# Altium schematic sheet S7CAS-PLEIADES-CARTE-MERE_P01_ETHERNET.SchDoc
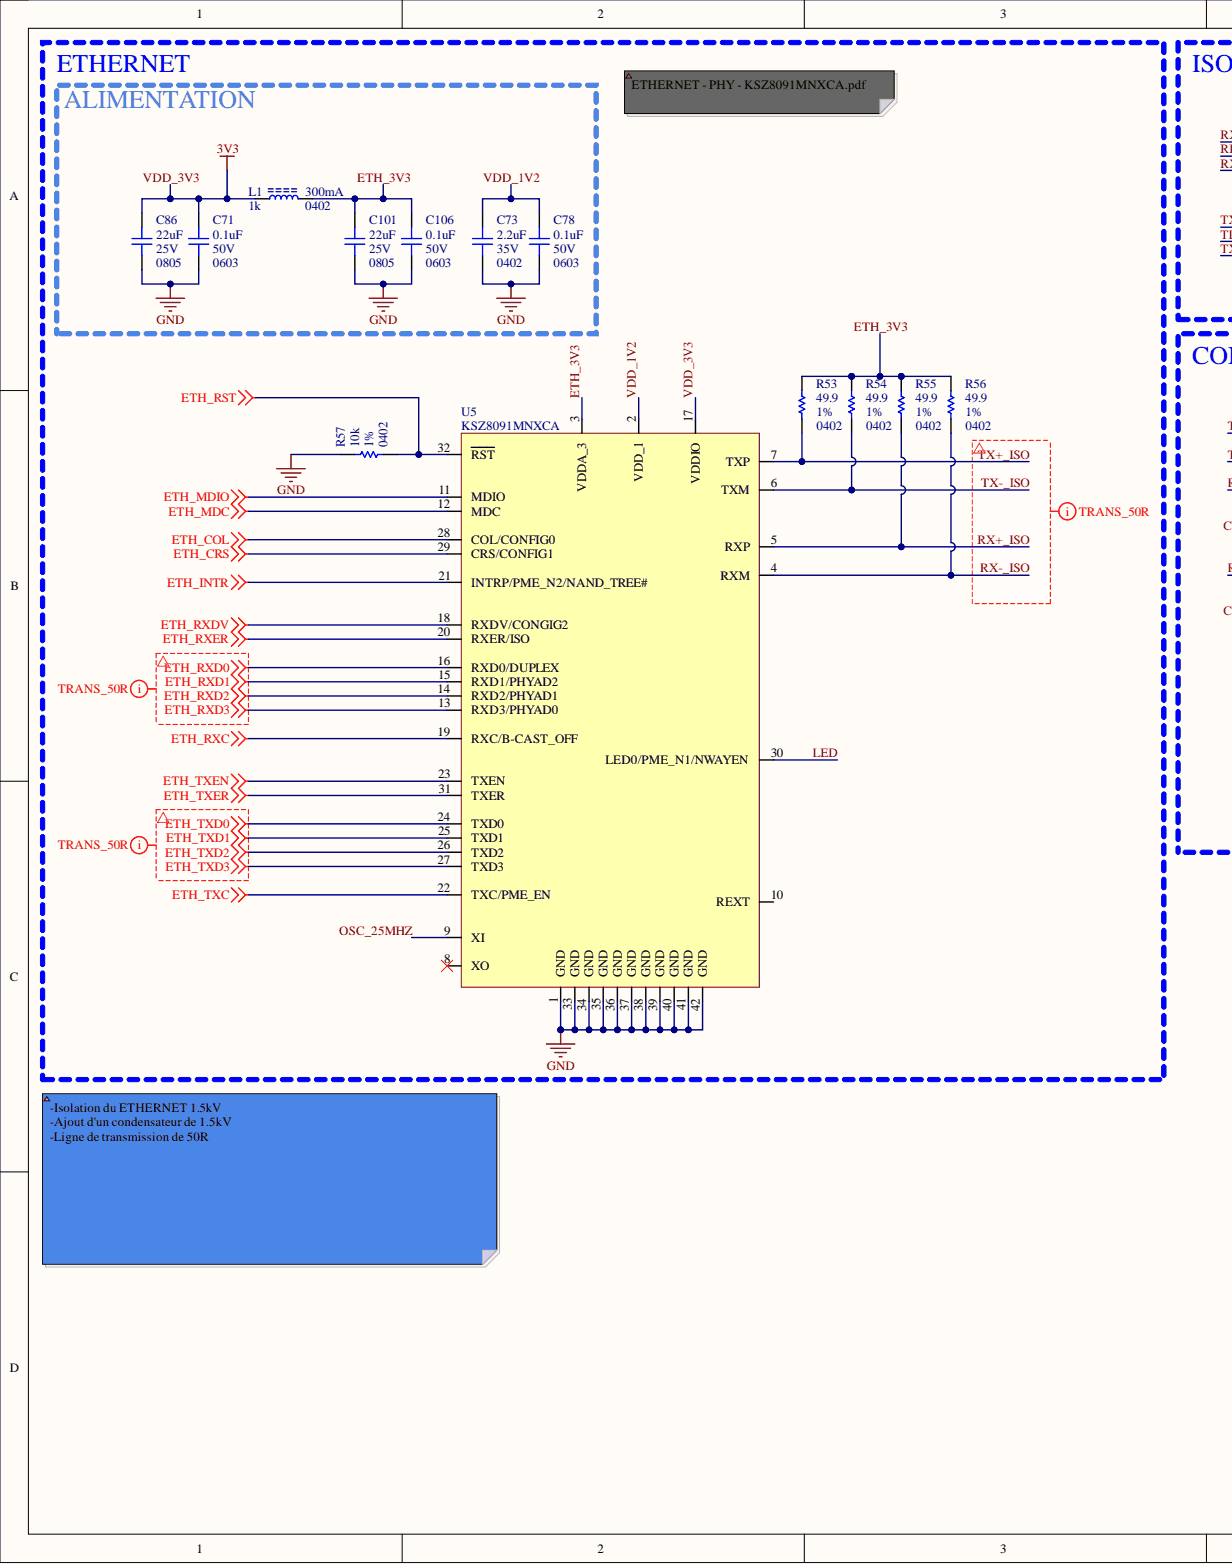
[diagram p0: S7CAS-PLEIADES-CARTE-MERE_P01_ETHERNET.SchDoc, left]
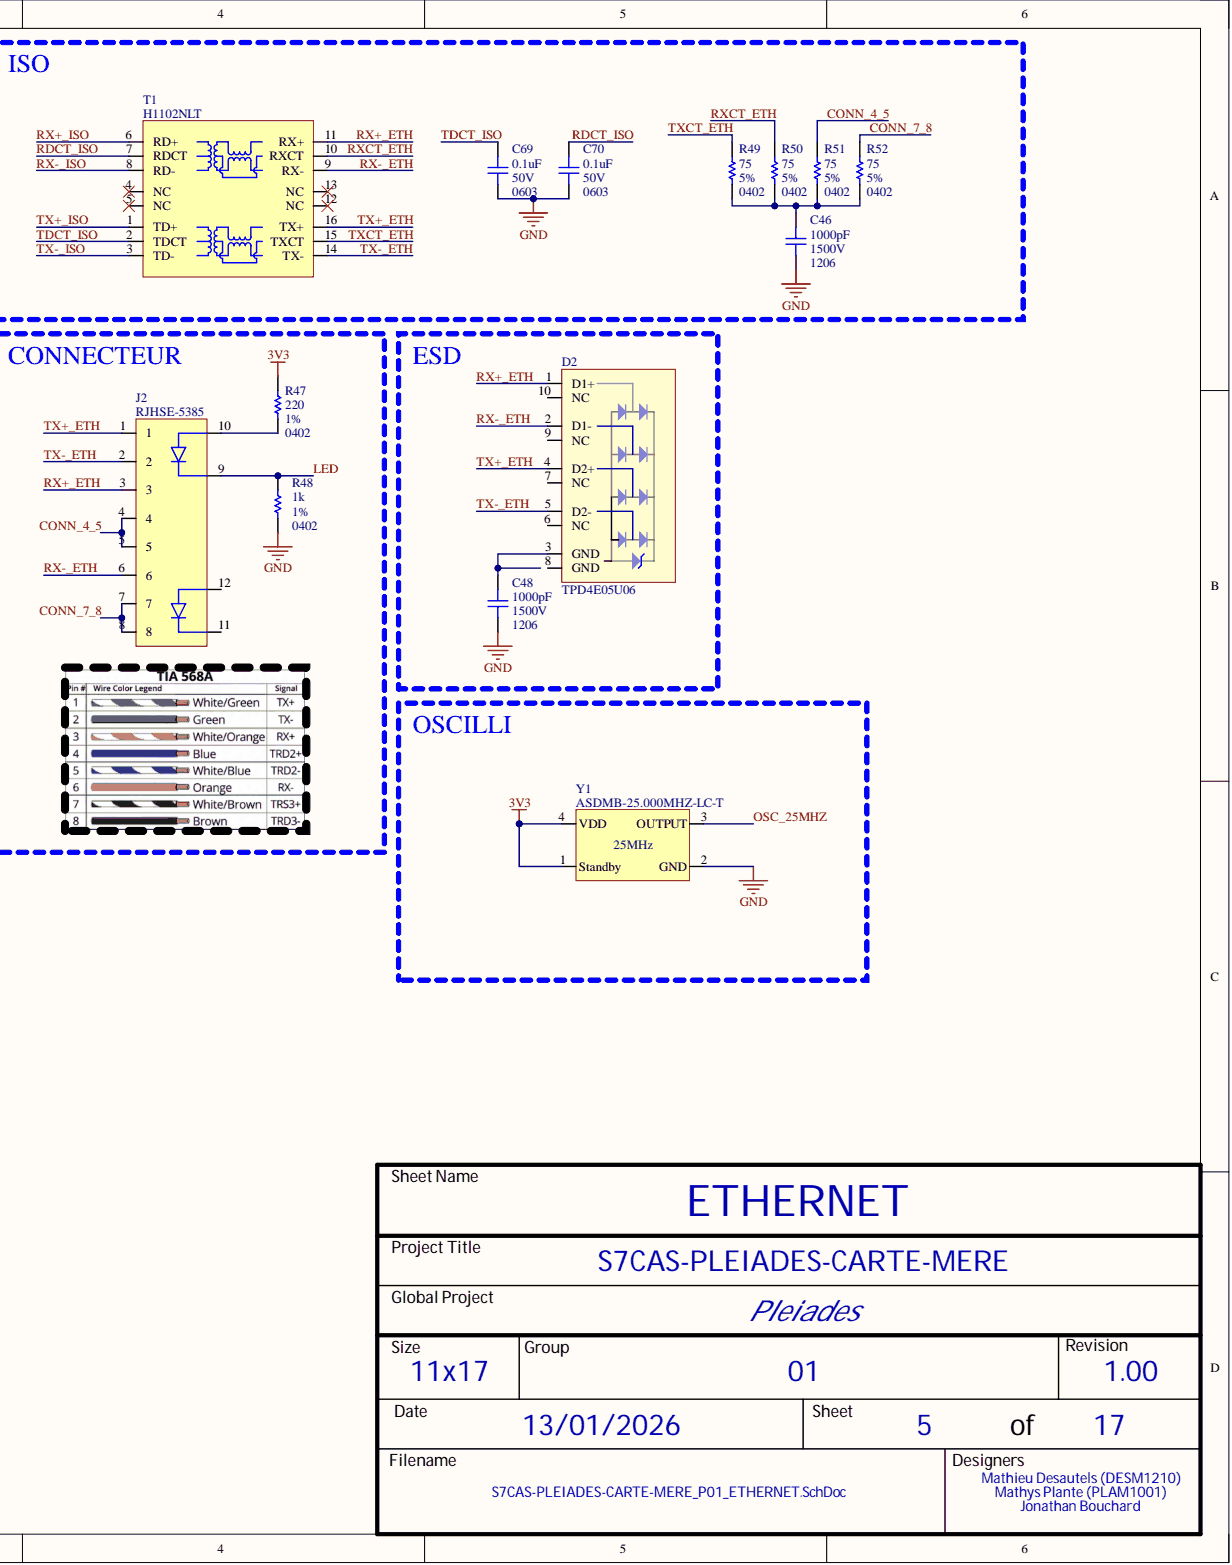
[diagram p1: S7CAS-PLEIADES-CARTE-MERE_P01_ETHERNET.SchDoc, right]

## Parts
C46  value 1000pF  Package: 1206  Voltage: 1500V  [CAP_1206]  (hidden comment: CAP - 1206)
C48  value 1000pF  Package: 1206  Voltage: 1500V  [CAP_1206]  (hidden comment: CAP - 1206)
C69  value 0.1uF  Package: 0603  Voltage: 50V  [CAP_0603]  (hidden comment: CAP - 0603)
C70  value 0.1uF  Package: 0603  Voltage: 50V  [CAP_0603]  (hidden comment: CAP - 0603)
C71  value 0.1uF  Package: 0603  Voltage: 50V  [CAP_0603]  (hidden comment: CAP - 0603)
C73  value 2.2uF  Package: 0402  Voltage: 35V  [CAP_0402]  (hidden comment: CAP - 0402)
C78  value 0.1uF  Package: 0603  Voltage: 50V  [CAP_0603]  (hidden comment: CAP - 0603)
C86  value 22uF  Package: 0805  Voltage: 25V  [CAP_0805]  (hidden comment: CAP - 0805)
C101  value 22uF  Package: 0805  Voltage: 25V  [CAP_0805]  (hidden comment: CAP - 0805)
C106  value 0.1uF  Package: 0603  Voltage: 50V  [CAP_0603]  (hidden comment: CAP - 0603)
D2  TPD4E05U06  [TVS_DATA_TPD4E05U06]
J2  RJHSE-5385  [CONN_ETHERNET_RJHSE-5385]
L1  value 1k  Current: 300mA  Package: 0402  [FER_0402]  (hidden comment: FER - 0402)
R47  value 220  Package: 0402  Precision: 1%  [RES_0402]  (hidden comment: RES - 0402)
R48  value 1k  Package: 0402  Precision: 1%  [RES_0402]  (hidden comment: RES - 0402)
R49  value 75  Package: 0402  Precision: 5%  [RES_0402]  (hidden comment: RES - 0402)
R50  value 75  Package: 0402  Precision: 5%  [RES_0402]  (hidden comment: RES - 0402)
R51  value 75  Package: 0402  Precision: 5%  [RES_0402]  (hidden comment: RES - 0402)
R52  value 75  Package: 0402  Precision: 5%  [RES_0402]  (hidden comment: RES - 0402)
R53  value 49.9  Precision: 1%  [RES_0402]  (hidden comment: RES - 0402)
R54  value 49.9  Package: 0402  Precision: 1%  [RES_0402]  (hidden comment: RES - 0402)
R55  value 49.9  Package: 0402  Precision: 1%  [RES_0402]  (hidden comment: RES - 0402)
R56  value 49.9  Package: 0402  Precision: 1%  [RES_0402]  (hidden comment: RES - 0402)
R57  value 10k  Package: 0402  Precision: 1%  [RES_0402]  (hidden comment: RES - 0402)
T1  H1102NLT  [TRANSFO_H1102NLT]
U5  KSZ8091MNXCA  [COM_ETH_PHY_KSZ8091MNXCA]
Y1  ASDMB-25.000MHZ-LC-T  value 25MHz  [OSC_ASDMB-25.000MHZ-LC-T]

## Nets
ETH_COL: U5.28(COL/CONFIG0)
ETH_CRS: U5.29(CRS/CONFIG1)
ETH_INTR: U5.21(INTRP/PME_N2/NAND_TREE#)
ETH_MDC: U5.12(MDC)
ETH_MDIO: U5.11(MDIO)
ETH_RST: R57.2 U5.32(RST)
ETH_RXC: U5.19(RXC/B-CAST_OFF)
ETH_RXD0: U5.16(RXD0/DUPLEX)
ETH_RXD1: U5.15(RXD1/PHYAD2)
ETH_RXD2: U5.14(RXD2/PHYAD1)
ETH_RXD3: U5.13(RXD3/PHYAD0)
ETH_RXDV: U5.18(RXDV/CONGIG2)
ETH_RXER: U5.20(RXER/ISO)
ETH_TXC: U5.22(TXC/PME_EN)
ETH_TXD0: U5.24(TXD0)
ETH_TXD1: U5.25(TXD1)
ETH_TXD2: U5.26(TXD2)
ETH_TXD3: U5.27(TXD3)
ETH_TXEN: U5.23(TXEN)
ETH_TXER: U5.31(TXER)
GND: C46.1 C48.1 C69.1 C70.1 C71.1 C73.1 C78.1 C86.1 C101.1 C106.1 R48.1 R57.1 U5.1(GND) U5.33(GND) U5.34(GND) U5.35(GND) U5.36(GND) U5.37(GND) U5.38(GND) U5.39(GND) U5.40(GND) U5.41(GND) U5.42(GND) Y1.2(GND)
CONN_4_5: J2.4 J2.5 R51.2
CONN_7_8: J2.7 J2.8 R52.2
ETH_3V3: C101.2 C106.2 L1.1 R53.2 R54.2 R55.2 R56.2 U5.3(VDDA_3)
LED: J2.9 R48.2 U5.30(LED0/PME_N1/NWAYEN)
OSC_25MHZ: U5.9(XI) Y1.3(OUTPUT)
RDCT_ISO: C70.2 T1.7(RDCT)
RX+_ETH: D2.1(D1+) J2.3 T1.11(RX+)
RX+_ISO: R55.1 T1.6(RD+) U5.5(RXP)
RX-_ETH: D2.2(D1-) J2.6 T1.9(RX-)
RX-_ISO: R56.1 T1.8(RD-) U5.4(RXM)
RXCT_ETH: R50.2 T1.10(RXCT)
TDCT_ISO: C69.2 T1.2(TDCT)
TX+_ETH: D2.4(D2+) J2.1 T1.16(TX+)
TX+_ISO: R53.1 T1.1(TD+) U5.7(TXP)
TX-_ETH: D2.5(D2-) J2.2 T1.14(TX-)
TX-_ISO: R54.1 T1.3(TD-) U5.6(TXM)
TXCT_ETH: R49.2 T1.15(TXCT)
VDD_1V2: C73.2 C78.2 U5.2(VDD_1)
VDD_3V3: C71.2 C86.2 L1.2 R47.2 U5.17(VDDIO) Y1.1(Standby) Y1.4(VDD)
NetC46_2: C46.2 R49.1 R50.1 R51.1 R52.1
NetC48_2: C48.2 D2.3(GND)
NetJ2_10: J2.10 R47.1

Not connected: D2 6(NC) 7(NC) 8(GND) 9(NC) 10(NC); J2 11 12; T1 4(NC) 5(NC) 12(NC) 13(NC); U5 8(XO) 10(REXT)

## Library data of the parts
C46 C48 = CAP - 1206: 1000 pF ±5% 1500V (1.5kV) Ceramic Capacitor C0G, NP0 1206 (3216 Metric); Mfg Part Number: VJ1206A102JXRAT5Z; Mfg: Vishay Vitramon; Component Kind: Standard; Library Name: s7cas_lib2023.intlib; Part Number #1: VJ1206A102JXRAT5Z; Precision: 5%; CreatedBy: Jonathan Bouchard
C69-C71 C78 C106 = CAP - 0603: 0.1 µF ±10% 50V Ceramic Capacitor X7R 0603 (1608 Metric); Mfg Part Number: C0603C104K5RACTU; Mfg: KEMET; Component Kind: Standard; Library Name: s7cas_lib2023.intlib; Precision: 10%; CreatedBy: Jonathan Bouchard
C73 = CAP - 0402: 2.2 µF ±10% 35V Ceramic Capacitor X5R 0402 (1005 Metric); Mfg Part Number: GRM155R6YA225KE11D; Part Number #1: CL05A225KP5NSNC; Mfg: Murata Electronics; Component Kind: Standard; Library Name: s7cas_lib2023.intlib; Precision: 10%; CreatedBy: Jonathan Bouchard
C86 C101 = CAP - 0805: 22 µF ±20% 25V Ceramic Capacitor X5R 0805 (2012 Metric); Mfg Part Number: CL21A226MAYNNNE; Mfg: Samsung Electro-Mechanics; Component Kind: Standard; Library Name: s7cas_lib2023.intlib; Precision: 20%; CreatedBy: Jonathan Bouchard
D2 = TVS_DATA_TPD4E05U06: TVS - TVS DIODE 5.5VWM 14VC - 10SON; Manufacturer #1: Texas Instruments; Mfg Part Number: TPD4E05U06DQAR; Cryo: UNKNOWN; Current Rating: 2.5A (8/20µs); GRAMS Lib Spec: 2.8; Polarized: YES; Power Max: 40 W (peak); Value: 4 unidirectionnal channels; Voltage rating: 6V (Breakdown); Component Kind: Standard; HelpURL: http://www.ti.com/lit/ds/symlink/tpd4e05u06.pdf; Library Name: s7cas_lib2023.intlib; Magnetic Material: UNKNOWN; Package: 10-USON; Part Number #1: TPD4E05U06DQAR; Supplier 1: Digi-Key; Supplier Part Number 1: 296-35765-1-ND; Temperature: -40°C ~ 125°C; CreatedBy/Date: Olivier Bernard / 12/3/2018; VerifiedBy: Caroline Paulin / 4 juillet 2018
J2 = CONN_ETHERNET_RJHSE-5385: CONN - MOD JACK RJ45 R/A ETHERNET - TH; Mfg: Amphenol; Component Kind: Standard; HelpURL: https://www.amphenolcanada.com/ProductSearch/drawings/AC/RJHSE538X.pdf; Library Name: s7cas_lib2023.intlib; Mfg Part Number: RJHSE-5385; Package: TH-12; Part Number #1: RJHSE-5385; Supplier 1: Digikey; Supplier Part Number 1: RJHSE-5385-ND; Temperature: -55°C ~ 85°C; CreatedBy: Jonathan Bouchard
L1 = FER - 0402: 1 kOhms @ 100 MHz 1 Ferrite Bead 0402 (1005 Metric) 300mA 650mOhm; Mfg Part Number: BLM15AG102SN1D; Mfg: Murata Electronics; Component Kind: Standard; Library Name: s7cas_lib2023.intlib; Part Number #1: BLM15AG102SN1D; CreatedBy: Jonathan Bouchard
R47 = RES - 0402: 220 Ohms ±1% 0.1W, 1/10W Chip Resistor 0402 (1005 Metric) Automotive AEC-Q200 Thick Film220; Mfg Part Number: ERJ-2RKF2200X; Mfg: Panasonic Electronic Components; Voltage: 50V; Component Kind: Standard; Library Name: s7cas_lib2023.intlib; Part Number #1: ERJ-2RKF2200X; Power: 1/10W; CreatedBy: Jonathan Bouchard
R48 = RES - 0402: 1 kOhms ±1% 0.1W, 1/10W Chip Resistor 0402 (1005 Metric) Automotive AEC-Q200 Thick Film; Mfg Part Number: ERJ-2RKF1001X; Mfg: Panasonic Electronic Components; Voltage: 50V; Component Kind: Standard; Library Name: s7cas_lib2023.intlib; Part Number #1: ERJ-2RKF1001X; Power: 1/10W; CreatedBy: Jonathan Bouchard
R49-R52 = RES - 0402: 75 Ohms ±5% 0.063W, 1/16W Chip Resistor 0402 (1005 Metric) Moisture Resistant Thick Film; Mfg Part Number: RC0402JR-0775RL; Mfg: YAGEO; Voltage: 50V; Component Kind: Standard; Library Name: s7cas_lib2023.intlib; Part Number #1: RC0402JR-0775RL; Power: 1/16W; CreatedBy: Jonathan Bouchard
R53-R56 = RES - 0402: 49.9 Ohms ±1% 0.1W, 1/10W Chip Resistor 0402 (1005 Metric) Automotive AEC-Q200 Thick Film; Mfg Part Number: CRCW040249R9FKED; Mfg: Vishay Dale; Voltage: 75V; Component Kind: Standard; Library Name: s7cas_lib2023.intlib; Part Number #1: CRCW040249R9FKED; Power: 1/10W; CreatedBy: Jonathan Bouchard
  R53: Package: 0402
R57 = RES - 0402: 10 kOhms ±1% 0.063W, 1/16W Chip Resistor 0402 (1005 Metric) Automotive AEC-Q200 Thick Film; Mfg Part Number: RMCF0402FT10K0; Voltage: 75V; Component Kind: Standard; Library Name: s7cas_lib2023.intlib; Part Number #1: RMCF0402FT10K0; Power: 1/16W; CreatedBy: Jonathan Bouchard
T1 = TRANSFO_H1102NLT: TRANSFO - ETHERNET 10/100B-TX - SMD-16; Mfg: Pulse Eng.; Value: 1:1 (350uH); Component Kind: Standard; HelpURL: http://media.digikey.com/pdf/Data%20Sheets/Pulse%20PDFs/10_100BASE-T%20Single%20Port%20SMD%20Magnetics_Rev2008.pdf; Library Name: s7cas_lib2023.intlib; Mfg Part Number: H1102NLT; Package: SMD-16; Part Number #1: H1102NLT; Supplier 1: Digikey; Supplier Part Number 1: 553-1532-1-ND; Temperature: -40°C to 85°C; CreatedBy: Jonathan Bouchard
U5 = COM_ETH_PHY_KSZ8091MNXCA: COM - ETHERNET PHY 10/100 - QFN-32; Mfg: Micrel; Voltage: 1.8V, 2.5V, 3.3V; Component Kind: Standard; HelpURL: http://ww1.microchip.com/downloads/en/DeviceDoc/00002275A.pdf; Library Name: s7cas_lib2023.intlib; Mfg Part Number: KSZ8091MNXCA; Package: QFN-32; Part Number #1: KSZ8091MNXCA; Supplier 1: Digikey; Supplier Part Number 1: 576-4356-ND; Temperature: 0°C ~ 70°C; CreatedBy: Jonathan Bouchard
Y1 = OSC_ASDMB-25.000MHZ-LC-T: OSC - 25MHz XO (Standard) LVCMOS - 4-SMD, No Lead; Manufacturer #1: Abracon LLC; Cryo: UNKNOWN; GRAMS Lib Spec: 2.8; Polarized: Yes; Tolerance: ±50ppm; Voltage Rating: 1.8V ~ 3.3V; Component Kind: Standard; HelpURL: https://abracon.com/Oscillators/ASDMB.pdf; Library Name: s7cas_lib2023.intlib; Magnetic Material: Unknown; Mfg Part Number: ASDMB-25.000MHZ-LC-T; Package: 4-SMD, No Lead; Part Number #1: ASDMB-25.000MHZ-LC-T; Supplier 1: Digi-Key; Supplier Part Number 1: 535-11730-1-ND; Temperature: -40°C ~ +85°C; CreatedBy/Date: Joël Lemay 2019-03-29; VerifiedBy: C. Paulin - 29 mai 2019
